# Altium schematic sheet DigitalInput.SchDoc
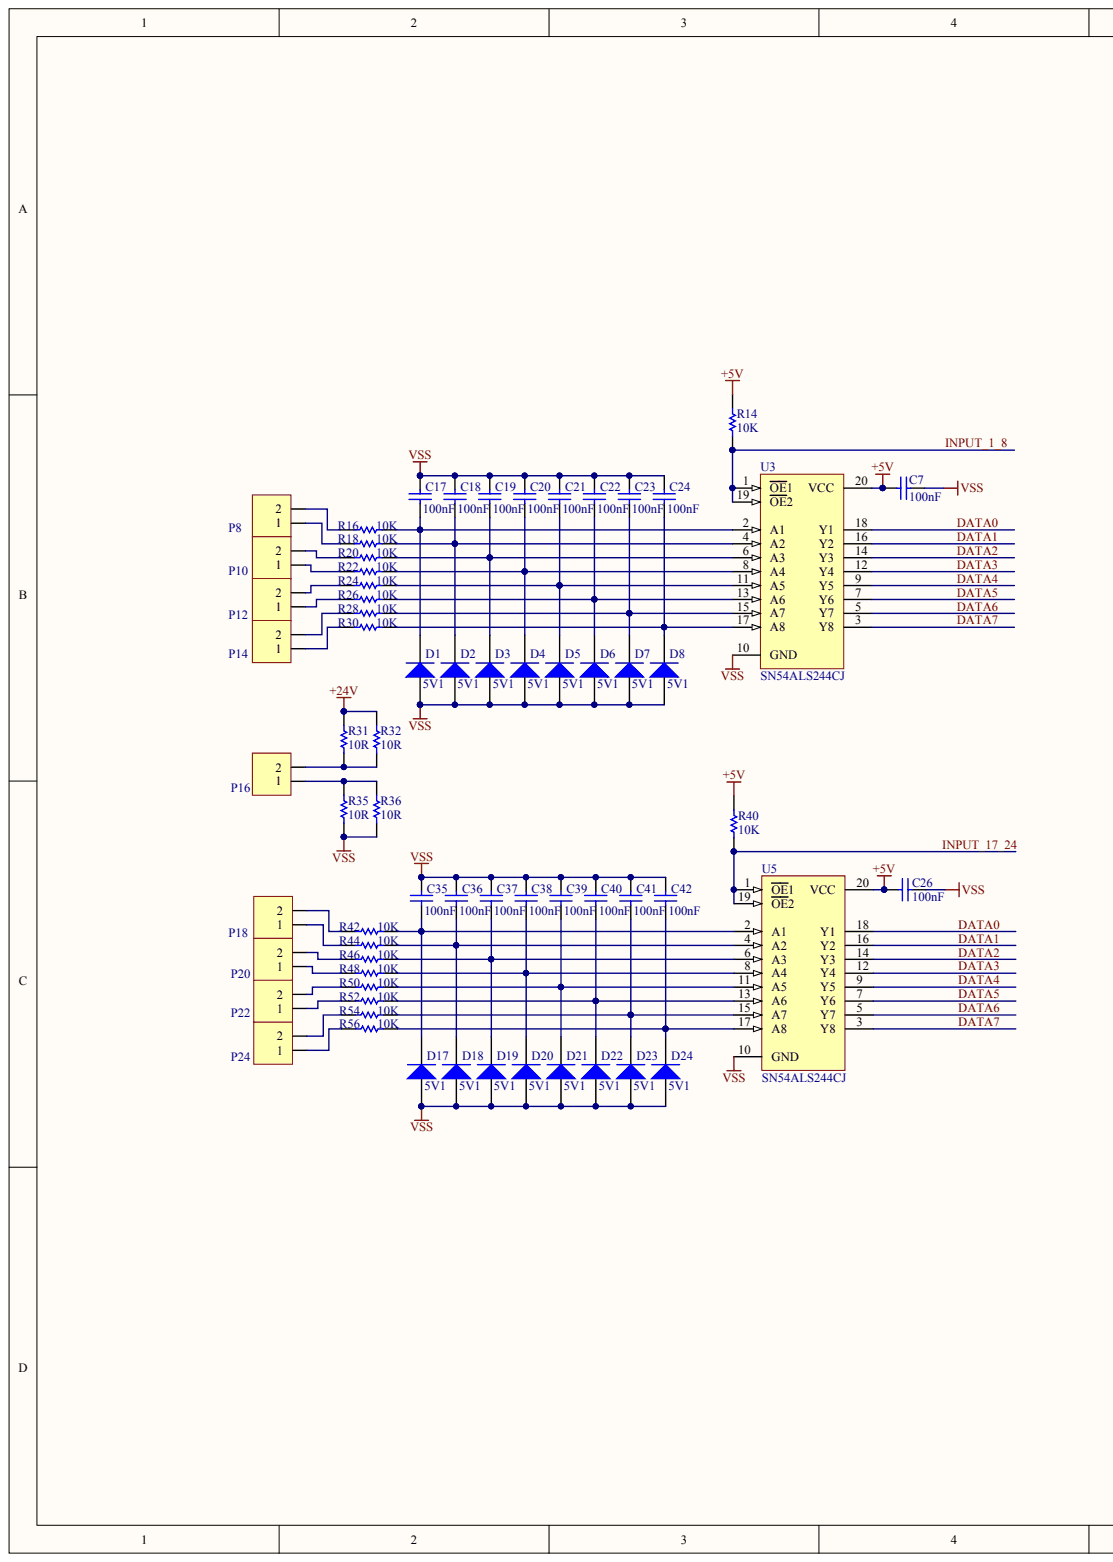
[diagram p0: DigitalInput.SchDoc, left]
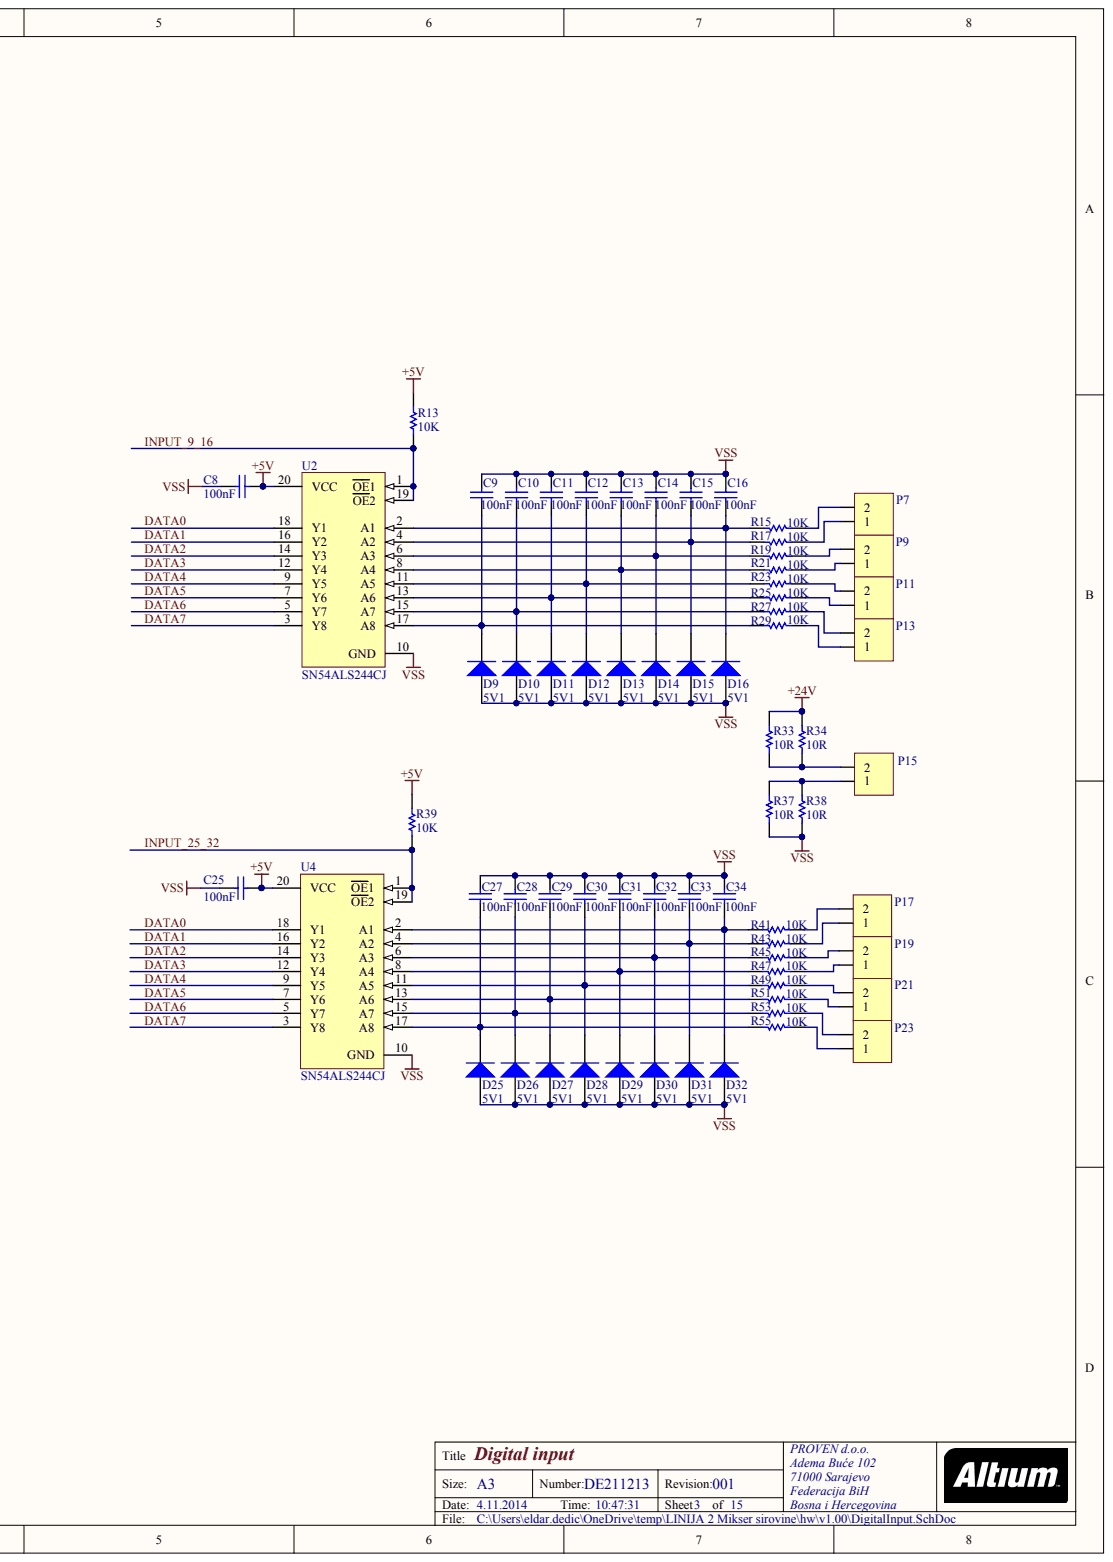
[diagram p1: DigitalInput.SchDoc, right]

## Parts
C7  value 100nF
C8  value 100nF
C9  value 100nF
C10  value 100nF
C11  value 100nF
C12  value 100nF
C13  value 100nF
C14  value 100nF
C15  value 100nF
C16  value 100nF
C17  value 100nF
C18  value 100nF
C19  value 100nF
C20  value 100nF
C21  value 100nF
C22  value 100nF
C23  value 100nF
C24  value 100nF
C25  value 100nF
C26  value 100nF
C27  value 100nF
C28  value 100nF
C29  value 100nF
C30  value 100nF
C31  value 100nF
C32  value 100nF
C33  value 100nF
C34  value 100nF
C35  value 100nF
C36  value 100nF
C37  value 100nF
C38  value 100nF
C39  value 100nF
C40  value 100nF
C41  value 100nF
C42  value 100nF
D1  5V1
D2  5V1
D3  5V1
D4  5V1
D5  5V1
D6  5V1
D7  5V1
D8  5V1
D9  5V1
D10  5V1
D11  5V1
D12  5V1
D13  5V1
D14  5V1
D15  5V1
D16  5V1
D17  5V1
D18  5V1
D19  5V1
D20  5V1
D21  5V1
D22  5V1
D23  5V1
D24  5V1
D25  5V1
D26  5V1
D27  5V1
D28  5V1
D29  5V1
D30  5V1
D31  5V1
D32  5V1
P7  (no value)
P8  (no value)
P9  (no value)
P10  (no value)
P11  (no value)
P12  (no value)
P13  (no value)
P14  (no value)
P15  (no value)
P16  (no value)
P17  (no value)
P18  (no value)
P19  (no value)
P20  (no value)
P21  (no value)
P22  (no value)
P23  (no value)
P24  (no value)
R13  10K
R14  10K
R15  10K
R16  10K
R17  10K
R18  10K
R19  10K
R20  10K
R21  10K
R22  10K
R23  10K
R24  10K
R25  10K
R26  10K
R27  10K
R28  10K
R29  10K
R30  10K
R31  10R
R32  10R
R33  10R
R34  10R
R35  10R
R36  10R
R37  10R
R38  10R
R39  10K
R40  10K
R41  10K
R42  10K
R43  10K
R44  10K
R45  10K
R46  10K
R47  10K
R48  10K
R49  10K
R50  10K
R51  10K
R52  10K
R53  10K
R54  10K
R55  10K
R56  10K
U2  SN54ALS244CJ
U3  SN54ALS244CJ
U4  SN54ALS244CJ
U5  SN54ALS244CJ

## Nets
+5V: C7.1 C8.1 C25.1 C26.1 R13.2 R14.2 R39.2 R40.2 U2.20(VCC) U3.20(VCC) U4.20(VCC) U5.20(VCC)
+24V: R31.2 R32.2 R33.2 R34.2
VSS: C7.2 C8.2 C9.2 C10.2 C11.2 C12.2 C13.2 C14.2 C15.2 C16.2 C17.2 C18.2 C19.2 C20.2 C21.2 C22.2 C23.2 C24.2 C25.2 C26.2 C27.2 C28.2 C29.2 C30.2 C31.2 C32.2 C33.2 C34.2 C35.2 C36.2 C37.2 C38.2 C39.2 C40.2 C41.2 C42.2 D1.1 D2.1 D3.1 D4.1 D5.1 D6.1 D7.1 D8.1 D9.1 D10.1 D11.1 D12.1 D13.1 D14.1 D15.1 D16.1 D17.1 D18.1 D19.1 D20.1 D21.1 D22.1 D23.1 D24.1 D25.1 D26.1 D27.1 D28.1 D29.1 D30.1 D31.1 D32.1 R35.1 R36.1 R37.1 R38.1 U2.10(GND) U3.10(GND) U4.10(GND) U5.10(GND)
DATA0: U2.18(Y1) U3.18(Y1) U4.18(Y1) U5.18(Y1)
DATA1: U2.16(Y2) U3.16(Y2) U4.16(Y2) U5.16(Y2)
DATA2: U2.14(Y3) U3.14(Y3) U4.14(Y3) U5.14(Y3)
DATA3: U2.12(Y4) U3.12(Y4) U4.12(Y4) U5.12(Y4)
DATA4: U2.9(Y5) U3.9(Y5) U4.9(Y5) U5.9(Y5)
DATA5: U2.7(Y6) U3.7(Y6) U4.7(Y6) U5.7(Y6)
DATA6: U2.5(Y7) U3.5(Y7) U4.5(Y7) U5.5(Y7)
DATA7: U2.3(Y8) U3.3(Y8) U4.3(Y8) U5.3(Y8)
INPUT_1_8: R14.1 U3.1(OE1) U3.19(OE2)
INPUT_9_16: R13.1 U2.1(OE1) U2.19(OE2)
INPUT_17_24: R40.1 U5.1(OE1) U5.19(OE2)
INPUT_25_32: R39.1 U4.1(OE1) U4.19(OE2)
NetC9_1: C9.1 D9.2 R29.2 U2.17(A8)
NetC10_1: C10.1 D10.2 R27.2 U2.15(A7)
NetC11_1: C11.1 D11.2 R25.2 U2.13(A6)
NetC12_1: C12.1 D12.2 R23.2 U2.11(A5)
NetC13_1: C13.1 D13.2 R21.2 U2.8(A4)
NetC14_1: C14.1 D14.2 R19.2 U2.6(A3)
NetC15_1: C15.1 D15.2 R17.2 U2.4(A2)
NetC16_1: C16.1 D16.2 R15.2 U2.2(A1)
NetC17_1: C17.1 D1.2 R16.2 U3.2(A1)
NetC18_1: C18.1 D2.2 R18.2 U3.4(A2)
NetC19_1: C19.1 D3.2 R20.2 U3.6(A3)
NetC20_1: C20.1 D4.2 R22.2 U3.8(A4)
NetC21_1: C21.1 D5.2 R24.2 U3.11(A5)
NetC22_1: C22.1 D6.2 R26.2 U3.13(A6)
NetC23_1: C23.1 D7.2 R28.2 U3.15(A7)
NetC24_1: C24.1 D8.2 R30.2 U3.17(A8)
NetC27_1: C27.1 D25.2 R55.2 U4.17(A8)
NetC28_1: C28.1 D26.2 R53.2 U4.15(A7)
NetC29_1: C29.1 D27.2 R51.2 U4.13(A6)
NetC30_1: C30.1 D28.2 R49.2 U4.11(A5)
NetC31_1: C31.1 D29.2 R47.2 U4.8(A4)
NetC32_1: C32.1 D30.2 R45.2 U4.6(A3)
NetC33_1: C33.1 D31.2 R43.2 U4.4(A2)
NetC34_1: C34.1 D32.2 R41.2 U4.2(A1)
NetC35_1: C35.1 D17.2 R42.2 U5.2(A1)
NetC36_1: C36.1 D18.2 R44.2 U5.4(A2)
NetC37_1: C37.1 D19.2 R46.2 U5.6(A3)
NetC38_1: C38.1 D20.2 R48.2 U5.8(A4)
NetC39_1: C39.1 D21.2 R50.2 U5.11(A5)
NetC40_1: C40.1 D22.2 R52.2 U5.13(A6)
NetC41_1: C41.1 D23.2 R54.2 U5.15(A7)
NetC42_1: C42.1 D24.2 R56.2 U5.17(A8)
NetP7_1: P7.1 R17.1
NetP7_2: P7.2 R15.1
NetP8_1: P8.1 R18.1
NetP8_2: P8.2 R16.1
NetP9_1: P9.1 R21.1
NetP9_2: P9.2 R19.1
NetP10_1: P10.1 R22.1
NetP10_2: P10.2 R20.1
NetP11_1: P11.1 R25.1
NetP11_2: P11.2 R23.1
NetP12_1: P12.1 R26.1
NetP12_2: P12.2 R24.1
NetP13_1: P13.1 R29.1
NetP13_2: P13.2 R27.1
NetP14_1: P14.1 R30.1
NetP14_2: P14.2 R28.1
NetP15_1: P15.1 R37.2 R38.2
NetP15_2: P15.2 R33.1 R34.1
NetP16_1: P16.1 R35.2 R36.2
NetP16_2: P16.2 R31.1 R32.1
NetP17_1: P17.1 R43.1
NetP17_2: P17.2 R41.1
NetP18_1: P18.1 R44.1
NetP18_2: P18.2 R42.1
NetP19_1: P19.1 R47.1
NetP19_2: P19.2 R45.1
NetP20_1: P20.1 R48.1
NetP20_2: P20.2 R46.1
NetP21_1: P21.1 R51.1
NetP21_2: P21.2 R49.1
NetP22_1: P22.1 R52.1
NetP22_2: P22.2 R50.1
NetP23_1: P23.1 R55.1
NetP23_2: P23.2 R53.1
NetP24_1: P24.1 R56.1
NetP24_2: P24.2 R54.1

## Library data of the parts
R13-R56 = (no symbol name): Note: If flow soldering, adjust pad width of PCB Footprint to 0.7 - 0.8 times the width of the resistor.; Published: 17-Dec-2003; LatestRevisionDate: 19-Mar-2004; LatestRevisionNote: Silkscreen size reduced.; PackageDocument: Mar-2003; ComponentLink1Description: Manufacturer Link; ComponentLink1URL: http://www.panasonic.com/industrial/components/resistive_products.htm; ComponentLink2Description: Datasheet; ComponentLink2URL: http://www.panasonic.com/industrial/components/pdf/AOA0000CE8.pdf; Publisher: Altium Limited
  R13-R30 R39-R56: PackageReference: ERA-0805; Code_IPC: 2012 [0805]
  R31-R38: PackageReference: ERA-0603; Code_IPC: 1608 [0603]
C7-C42 D1-D32 P7-P24 U2-U5 = (no symbol name)
  C7-C42: Published: 17-Jul-2002; LatestRevisionDate: 19-Mar-2004; LatestRevisionNote: Silkscreen size reduced.; DatasheetDocument: Mar-2001; PackageDocument: Mar-2001; PackageReference: 1206; Code_JEITA: 1206; Code_IPC: 3216 [1206]; ComponentLink1Description: Manufacturer Link; ComponentLink1URL: http://www.kemet.com/; ComponentLink2Description: Datasheet; ComponentLink2URL: http://www.kemet.com/kemet/web/homepage/kechome.nsf/vapubfilesname/F3102TA.pdf/$file/F3102TA.pdf
  D1-D32: Published: 17-Sep-2003; DatasheetVersion: 11-May-1999; PackageVersion: May-1999; PackageReference: SOD66; PackageDescription: Diode, Axial; Body 4.8 x 2.6 mm (LxDia. max), Lead Dia. 0.81 mm (max); Code_JEDEC: DO-204-AL; ComponentLink1Description: Manufacturer Link; ComponentLink1URL: http://www.semiconductors.philips.com/; ComponentLink2Description: Datasheet; ComponentLink2URL: http://www.semiconductors.philips.com/acrobat/datasheets/BZV85_2.pdf
  U2-U5: Published: 8-Jun-2000; LatestRevisionDate: 06-Jun-2005; LatestRevisionNote: Stylized 3D Model Added.; DatasheetVersion: Aug-1995; PackageVersion: Jun-1999; PackageReference: J020; PackageDescription: DIP; 20 Leads; Row Spacing 7.62 mm; Pitch 2.54 mm; ComponentLink1Description: Manufacturer Link; ComponentLink1URL: http://www.ti.com/; ComponentLink2Description: Datasheet; ComponentLink2URL: http://www-s.ti.com/sc/ds/sn74als244c.pdf
  C7-C42 D1-D32 U2-U5: Publisher: Altium Limited
